# Altium schematic sheet Airspeed1_B2.SchDoc
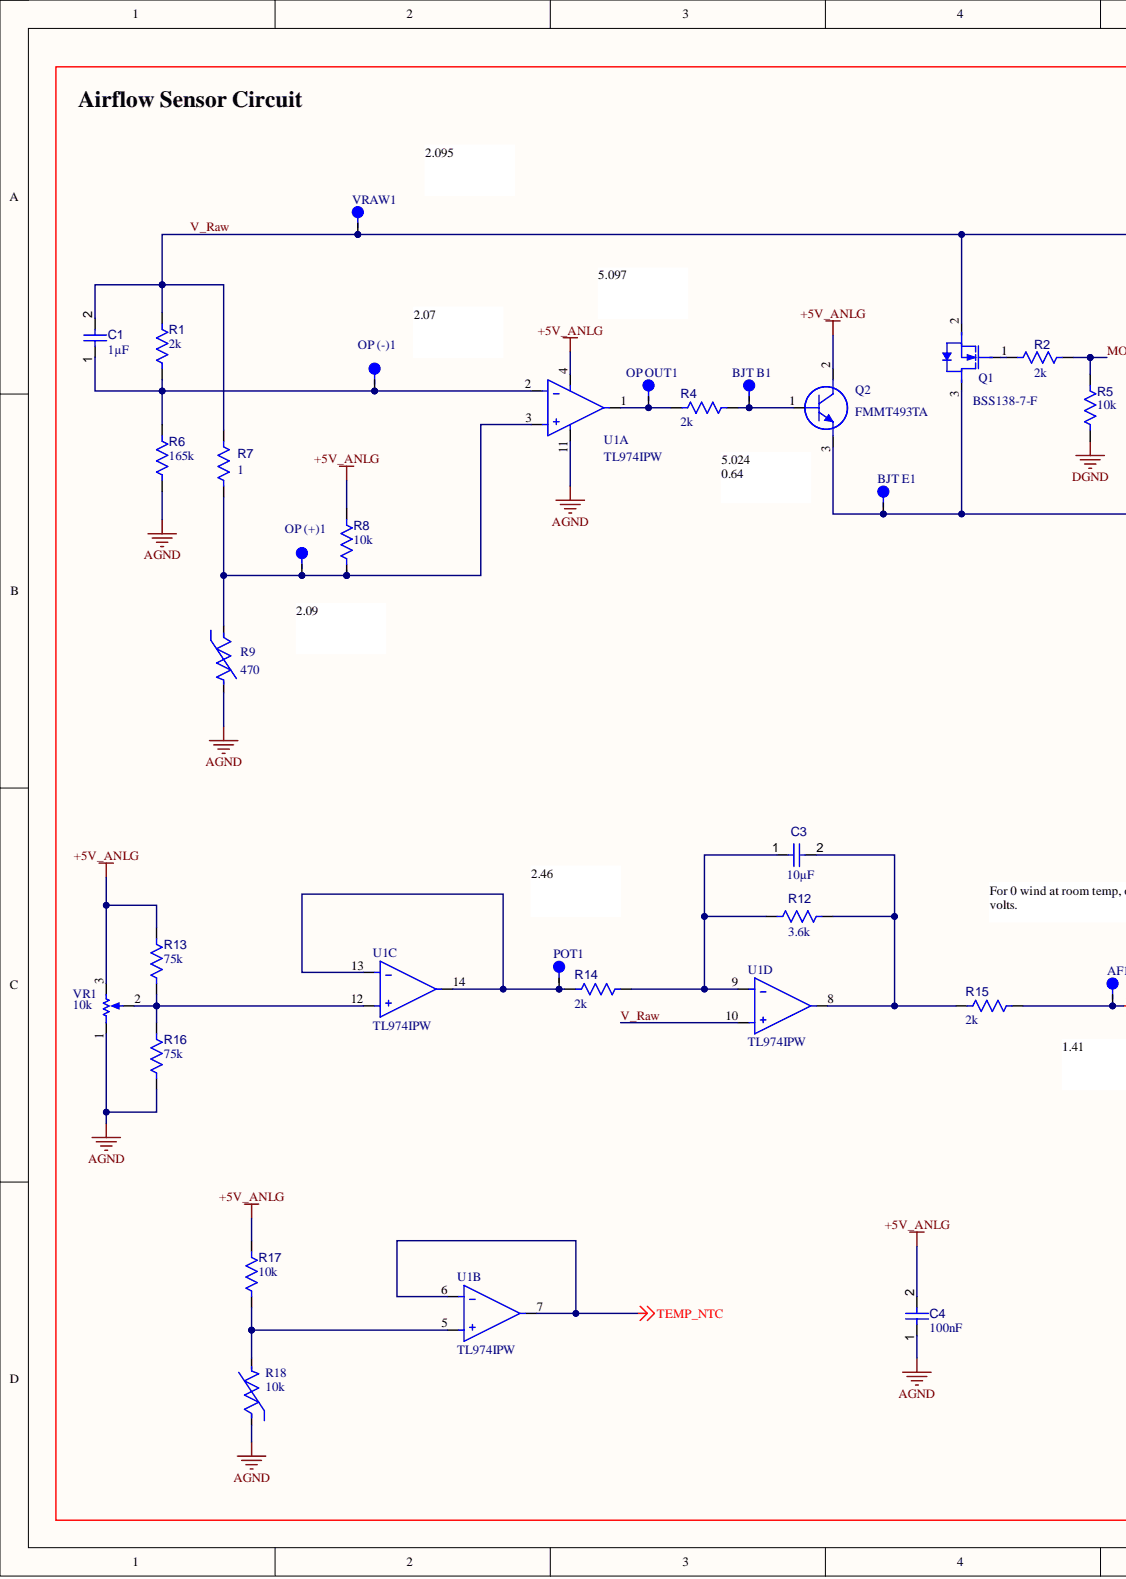
[diagram p0: Airspeed1_B2.SchDoc, left]
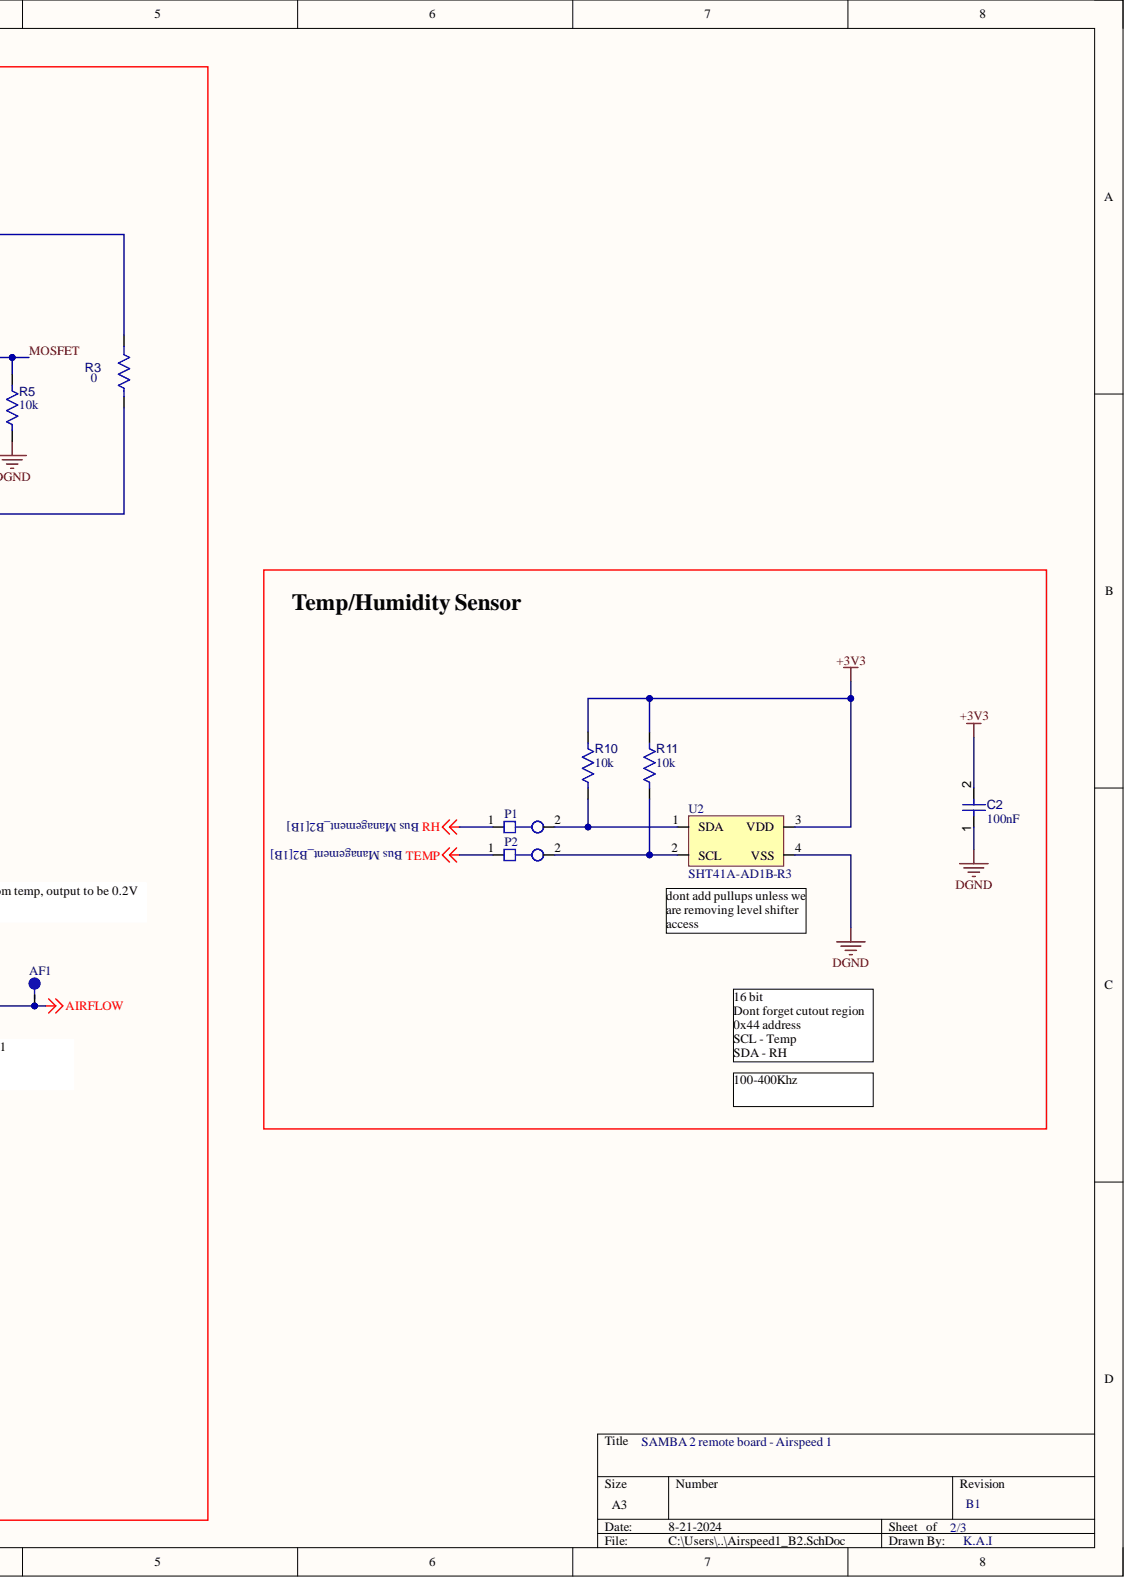
[diagram p1: Airspeed1_B2.SchDoc, right]

## Parts
AF1  PH1-01-UA  [FP-PH1-01-UA-MFG]  (value not drawn)
BJT_B1  PH1-01-UA  [FP-PH1-01-UA-MFG]  (value not drawn)
BJT_E1  PH1-01-UA  [FP-PH1-01-UA-MFG]  (value not drawn)
C1  value 1µF  [FP-CL10-IPC_C]  (hidden comment: CL10B105KA8NFNC)
C2  value 100nF  [FP-CC0603-DA-MFG]  (hidden comment: CC0603KRX7R7BB104)
C3  value 10µF  [FP-GRM188-0_15-MFG]  (hidden comment: GRM188R61A106ME69D)
C4  value 100nF  [FP-CC0603-DA-MFG]  (hidden comment: CC0603KRX7R7BB104)
OP_(+)1  PH1-01-UA  [FP-PH1-01-UA-MFG]  (value not drawn)
OP_(-)1  PH1-01-UA  [FP-PH1-01-UA-MFG]  (value not drawn)
OP_OUT1  PH1-01-UA  [FP-PH1-01-UA-MFG]  (value not drawn)
P1  PH1-02-UA  [PH1-02-UA-Footprint-1]  (value not drawn)
P2  PH1-02-UA  [PH1-02-UA-Footprint-1]  (value not drawn)
POT1  PH1-01-UA  [FP-PH1-01-UA-MFG]  (value not drawn)
Q1  BSS138-7-F  [DIOD-SOT23-3_V]
Q2  FMMT493TA  [SOT95P237X110-3N]
R1  value 2k  [IPC B 0603 RES]  (hidden comment: ERJ-3EKF2001V)
R2  value 2k  [IPC B 0603 RES]  (hidden comment: ERJ-3EKF2001V)
R3  value 0  [FP-RMCF0603-MFG]  (hidden comment: Resistor 0R +/- 5% 0603 100 mW)
R4  value 2k  [IPC B 0603 RES]  (hidden comment: ERJ-3EKF2001V)
R5  value 10k  [FP-RC0603-0_55-MFG]  (hidden comment: RC0603FR-0710KL)
R6  value 165k  [RESC1608X55X30NL15T15]  (hidden comment: ERJ-3EKF1653V)
R7  value 1  [Vishay_CRCW0805-HPe3_MFG]  (hidden comment: CRCW08051R00FKEAHP)
R8  value 10k  [FP-RC0603-0_55-MFG]  (hidden comment: RC0603FR-0710KL)
R9  value 470  [NTCG103NH471JT1-Footprint-1]  (hidden comment: NTCG103NH471JT1)
R10  value 10k  [FP-RC0603-0_55-MFG]  (hidden comment: RC0603FR-0710KL)
R11  value 10k  [FP-RC0603-0_55-MFG]  (hidden comment: RC0603FR-0710KL)
R12  value 3.6k  [RESC1609X50X30NL08T20]  (hidden comment: CRCW06033K60FKEAC)
R13  value 75k  [IPC B 0603 RES]  (hidden comment: AC0603FR-0775KL)
R14  value 2k  [IPC B 0603 RES]  (hidden comment: ERJ-3EKF2001V)
R15  value 2k  [IPC B 0603 RES]  (hidden comment: ERJ-3EKF2001V)
R16  value 75k  [IPC B 0603 RES]  (hidden comment: AC0603FR-0775KL)
R17  value 10k  [FP-RC0603-0_55-MFG]  (hidden comment: RC0603FR-0710KL)
R18  value 10k  [FP-NCP15-NCU15-0_05-MFG]  (hidden comment: NCP15XH103J03RC)
U1  TL974IPW  [TSOP65P640X120-14N]  (parts A,B,C,D)
U2  SHT41A-AD1B-R3  [SHT41A-AD1B-R3-Footprint-1]
VR1  value 10k  [22AR10KLFTR-Footprint-1]  (hidden comment: 22AR10KLFTR)
VRAW1  PH1-01-UA  [FP-PH1-01-UA-MFG]  (value not drawn)

## Nets
+3V3: C2.2 R10.2 R11.2 U2.3(VDD)
+5V_ANLG: C4.2 Q2.2 R8.2 R13.2 R17.2 U1.4 VR1.3
AGND: C4.1 R6.1 R9.2 R16.1 R18.1 U1.11 VR1.1
AIRFLOW: AF1.1 R15.1
DGND: C2.1 R5.1 U2.4(VSS)
RH: P1.1
TEMP: P2.1
TEMP_NTC: U1.6 U1.7
MOSFET: R2.1 R5.2
V_Raw: C1.2 Q1.2 R1.2 R3.2 R7.2 U1.10 VRAW1.1
NetBJT B1_1: BJT_B1.1 Q2.1 R4.1
NetBJT E1_1: BJT_E1.1 Q1.3 Q2.3 R3.1
NetC1_1: C1.1 OP_(-)1.1 R1.1 R6.2 U1.2
NetC3_1: C3.1 R12.1 R14.1 U1.9
NetC3_2: C3.2 R12.2 R15.2 U1.8
NetOP (+)1_1: OP_(+)1.1 R7.1 R8.1 R9.1 U1.3
NetOP OUT1_1: OP_OUT1.1 R4.2 U1.1
NetP1_2: P1.2 R10.1 U2.1(SDA)
NetP2_2: P2.2 R11.1 U2.2(SCL)
NetPOT1_1: POT1.1 R14.2 U1.13 U1.14
NetQ1_1: Q1.1 R2.2
NetR13_1: R13.1 R16.2 U1.12 VR1.2
NetR17_1: R17.1 R18.2 U1.5

## Library data of the parts
AF1 BJT_B1 BJT_E1 OP_(+)1 OP_(-)1 OP_OUT1 POT1 VRAW1 = CMP-002-00083-1: Conn Unshrouded Header HDR 1 POS 2.54mm Solder ST Thru-Hole Bag; Component Kind: Standard; Library Name: University of Sydney
C1 = CMP-001-00098-1: Ceramic Capacitor, Multilayer, Ceramic, 25V, 10% +Tol, 10% -Tol, X7R, 15% TC, 1uF, Surface Mount, 0603; Capacitor Type: Ceramic; Case/Package: 0603; Component Kind: Standard; Max Operating Temperature: 125°C; Min Operating Temperature: -55°C; Mounting Technology: Surface Mount; Pins: 2; RoHS Compliant: Yes; Library Name: University of Sydney
C2 C4 = CMP-001-00099-1: Ceramic Capacitor, Multilayer, Ceramic, 16V, 10% +Tol, 10% -Tol, X7R, 15% TC, 0.1uF, Surface Mount, 0603; Capacitor Type: Ceramic; Case/Package: 0603; Component Kind: Standard; Max Operating Temperature: 125°C; Min Operating Temperature: -55°C; Mounting Technology: Surface Mount; Pins: 2; RoHS Compliant: Yes; Library Name: University of Sydney
C3 = CMP-001-00097-1: Ceramic Capacitor, Multilayer, Ceramic, 10V, 20% +Tol, 20% -Tol, X5R, 15% TC, 10uF, Surface Mount, 0603; Capacitor Type: Ceramic; Case/Package: 0603; Component Kind: Standard; Max Operating Temperature: 85°C; Min Operating Temperature: -55°C; Mounting Technology: Surface Mount; Pins: 2; RoHS Compliant: Yes; Library Name: University of Sydney
P1 P2 = CMP-002-00078-1: Conn Unshrouded Header HDR 2 POS 2.54mm Solder ST Thru-Hole Bag; Component Kind: Standard; Library Name: University of Sydney
Q1 = CMP-00001-1: Small Signal Field-Effect Transistor, 0.2A I(D), 50V, 1-Element, N-Channel, Silicon, Metal-oxide Semiconductor FET; Drain Current-Max (Abs) (ID): 200mA; Drain-source On Resistance-Max: 3.5R; Feedback Cap-Max (Crss): 8pF; Number of Elements: 1; Operating Mode: ENHANCEMENT MODE; Package Body Material: Plastic; Power Dissipation-Max (Abs): 300mW; Qualification Status: Not Qualified; Time @ Peak Reflow Temperature-Max (s): 40s; Transistor Application: SWITCHING; Component Kind: Standard; Drain Current-Max (ID): 200mA; DS Breakdown Voltage-Min: 50V; FET Technology: METAL-OXIDE SEMICONDUCTOR; JESD-30 Code: R-PDSO-G3; JESD-609 Code: e3; Max Operating Temperature: 150°C; Moisture Sensitivity Level: 1; Package Shape: Rectangular; Package Style: SMALL OUTLINEMeter; Peak Reflow Temperature (Cel): 260°C; Pins: 3; Polarity/Channel Type: N-CHANNEL; Reach SVHC Compliant: Yes; RoHS Compliant: Yes; Surface Mount: Yes; Terminal Finish: Tin; Terminal Form: Gull Wing; Terminal Position: DUAL; Transistor Element Material: SILICON; Library Name: University of Sydney
Q2 = CMP-013-00034-1: Small Signal Bipolar Transistor, 1A I(C), 100V V(BR)CEO, 1-Element, NPN, Silicon; Frequency: 150MHz; Component Kind: Standard; Max Operating Temperature: 150°C; Pins: 3; RoHS Compliant: Yes; Library Name: University of Sydney
R1 R2 R4 R14 R15 = CMP-009-00207-1: Fixed Resistor, Metal Glaze/thick Film, 0.1W, 2000ohm, 75V, 1% +/-Tol, 100ppm/Cel, Surface Mount, 0603; Power: 100mW; Case/Package: 0603; Component Kind: Standard; Max Operating Temperature: 155°C; Min Operating Temperature: -55°C; Mounting Technology: Surface Mount; Pins: 2; RoHS Compliant: Yes; Tolerance: 1%; Library Name: University of Sydney
R3 = CMP-009-00006-7: Chip Resistor, 0 Ohm, 0.1 W, -55 to 155 degC, 0603 (1608 Metric); Power: 100mW; Voltage Rating: 50V; Case/Package: 0603; Component Kind: Standard; Max Operating Temperature: 155°C; Min Operating Temperature: -55°C; Mounting Technology: SM; Pins: 2; RoHS Compliant: True; Tolerance: 5%; Library Name: University of Sydney
R5 R8 R10 R11 R17 = CMP-009-00204-1: Fixed Resistor, Metal Glaze/thick Film, 0.1W, 10000ohm, 75V, 1% +/-Tol, 100ppm/Cel, Surface Mount, 0603; Power: 100mW; Case/Package: 0603; Component Kind: Standard; Max Operating Temperature: 155°C; Min Operating Temperature: -55°C; Mounting Technology: Surface Mount; Pins: 2; RoHS Compliant: Yes; Tolerance: 1%; Library Name: University of Sydney
R6 = CMP-009-00209-1: Fixed Resistor, Metal Glaze/thick Film, 0.1W, 165000ohm, 75V, 1% +/-Tol, 100ppm/Cel, Surface Mount, 0603; Power: 100mW; Case/Package: 0603; Component Kind: Standard; Max Operating Temperature: 155°C; Min Operating Temperature: -55°C; Mounting Technology: Surface Mount; Pins: 2; RoHS Compliant: Yes; Tolerance: 1%; Library Name: University of Sydney
R7 = CMP-009-00205-1: Fixed Resistor, Metal Glaze/thick Film, 0.5W, 1ohm, 150V, 1% +/-Tol, 100ppm/Cel, Surface Mount, 0805; Power: 500mW; Case/Package: 0805; Component Kind: Standard; Max Operating Temperature: 155°C; Min Operating Temperature: -55°C; Mounting Technology: Surface Mount; Pins: 2; RoHS Compliant: Yes; Tolerance: 1%; Library Name: University of Sydney
R9 = CMP-009-00192-3: NTC Thermistor, 470ohm, Surface Mount; Power: 100mW; Tolerance: 5%; Component Kind: Standard; Max Operating Temperature: 125°C; Min Operating Temperature: -40°C; Mounting Technology: Surface Mount; Pins: 2; RoHS Compliant: Yes; Library Name: University of Sydney
R12 = CMP-009-00200-1: Fixed Resistor, Metal Glaze/thick Film, 0.1W, 3600ohm, 75V, 1% +/-Tol, 100ppm/Cel, Surface Mount, 0603; Power: 100mW; Case/Package: 0603; Component Kind: Standard; Max Operating Temperature: 155°C; Min Operating Temperature: -55°C; Pins: 2; RoHS Compliant: Yes; Tolerance: 1%; Library Name: University of Sydney
R13 R16 = CMP-009-00208-1: Fixed Resistor, Metal Glaze/thick Film, 0.1W, 75000ohm, 75V, 1% +/-Tol, 100ppm/Cel, Surface Mount, 0603; Power: 100mW; Case/Package: 0603; Component Kind: Standard; Max Operating Temperature: 155°C; Min Operating Temperature: -55°C; Mounting Technology: Surface Mount; Pins: 2; RoHS Compliant: Yes; Tolerance: 1%; Library Name: University of Sydney
R18 = CMP-009-00202-2: NTC Thermistor, 10000ohm, Surface Mount; Power: 100mW; Tolerance: 5%; Case/Package: 0402; Component Kind: Standard; Max Operating Temperature: 125°C; Min Operating Temperature: -40°C; Mounting Technology: Surface Mount; Pins: 2; RoHS Compliant: Yes; Library Name: University of Sydney
U1 = CMP-014-00004-4: Operational Amplifier, 4 Func, 6000uV Offset-Max, BIPolar, PDSO14; Channels: 4; Nominal Supply Voltage: 2.5V; Case/Package: TSSOP; Component Kind: Standard; Max Operating Temperature: 125°C; Min Operating Temperature: -40°C; Pins: 14; RoHS Compliant: Yes; Library Name: University of Sydney
U2 = CMP-010-00024-1: Board Mount Humidity Sensors 4th Gen Humidity and Temperature Sensor; Component Kind: Standard; Library Name: University of Sydney
VR1 = CMP-009-00203-1: Trimmer, Cermet, 0.125W, 10000ohm, 200V, 20% +/-Tol, 100ppm/Cel, 1 Turn(s), 1413; Power: 125mW; Case/Package: 1214; Component Kind: Standard; Max Operating Temperature: 125°C; Min Operating Temperature: -55°C; Mounting Technology: Surface Mount; Pins: 3; RoHS Compliant: Yes; Tolerance: 20%; Library Name: University of Sydney
